# Altium schematic sheet screen.SchDoc(screen)
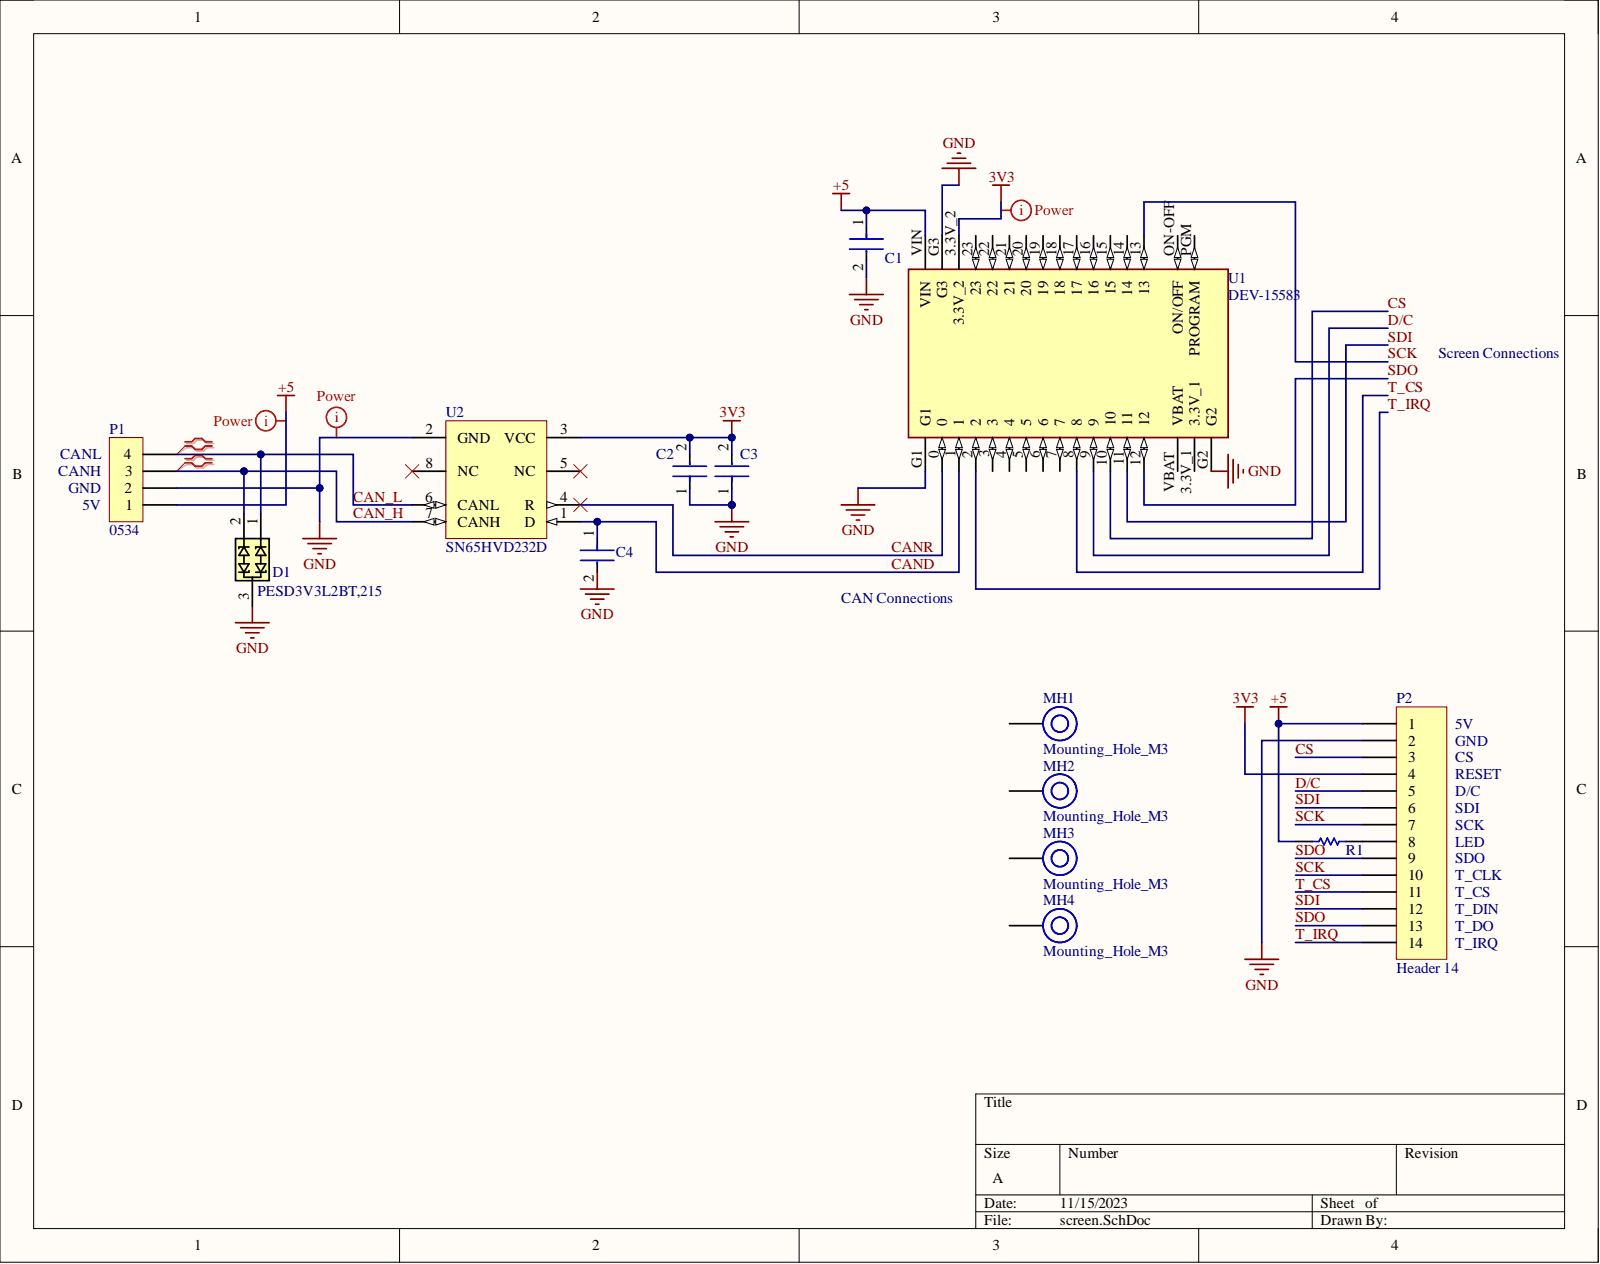
[diagram p0: screen.SchDoc]

## Parts
C1  CL21B104KACNNNC  (value not drawn)
C2  CL21B104KACNNNC  (value not drawn)
C3  CL21B104KACNNNC  (value not drawn)
C4  CL21B103KAANNNC  (value not drawn)
D1  PESD3V3L2BT,215
MH1  Mounting_Hole_M3  (no BOM)
MH2  Mounting_Hole_M3  (no BOM)
MH3  Mounting_Hole_M3  (no BOM)
MH4  Mounting_Hole_M3  (no BOM)
P1  0534
P2  Header 14
R1  RC0805FR-07100RL  (value not drawn)
U1  DEV-15583
U2  SN65HVD232D  [SN65HVD232]

## Nets
3V3: C2.2 C3.2 P2.4 U1.3.3V_2 U2.3(VCC)
+5: C1.1 P1.1 P2.1 R1.1 U1.VIN
GND: C1.2 C2.1 C3.1 C4.2 D1.3 P1.2 P2.2 U1.G1 U1.G2 U1.G3 U2.2(GND)
CAN_H: D1.2 P1.3 U2.7(CANH)
CAN_L: D1.1 P1.4 U2.6(CANL)
CAND: C4.1 U1.1 U2.1(D)
CANR: U1.0 U2.4(R)
CS: P2.3 U1.10
D/C: P2.5 U1.9
SCK: P2.7 P2.10 U1.13
SDI: P2.6 P2.12 U1.11
SDO: P2.9 P2.13 U1.12
T_CS: P2.11 U1.8
T_IRQ: P2.14 U1.2
NetP2_8: P2.8 R1.2

Not connected: MH1 1; MH2 1; MH3 1; MH4 1; U1 3 3.3V_1 4 5 6 7 14 15 16 17 18 19 20 21 22 23 ON-OFF(ON/OFF) PGM(PROGRAM) VBAT; U2 5(NC) 8(NC)

## Library data of the parts
C1-C3 = CMP-13271-002854-1: CL21 Series 0805 100nF 25V ±10% Tolerance X7R Multilayer Ceramic Chip Capacitor; Capacitance: 100nF; Case Code (Imperial): 0805; Case Code (Metric): 2012; Case/Package: 0805; Component Kind: Standard; Contact Plating: Tin; Depth: 1.25mm; Dielectric: X7R; Height: 0.85mm; Length: 2mm; Library Name: Altium Content Vault; Max Operating Temperature: 125°C; Min Operating Temperature: -55°C; Mount: Surface Mount; Package Quantity: 1; Packaging: Tape and Reel; Radiation Hardening: No; Thickness: 0.037inch; Tolerance: 10%; Voltage: 25V; Voltage Rating: 25V; Width: 0.049inch
C4 = CMP-13271-004188-1: CL21 Series 0805 10nF 25V ±10% Tolerance X7R Multilayer Ceramic Chip Capacitor; Tolerance: 20%; Voltage Rating: 50V; Capacitance: 10nF; Case Code (Imperial): 0805; Case Code (Metric): 2012; Case/Package: 0805; Component Kind: Standard; Depth: 1.25mm; Dielectric: X7R; Height: 0.65mm; Length: 2mm; Library Name: Altium Content Vault; Max Operating Temperature: 125°C; Min Operating Temperature: -55°C; Mount: Surface Mount; Package Quantity: 1; Packaging: Tape and Reel; Radiation Hardening: No; Thickness: 0.03inch; Voltage Rating (DC): 50V; Width: 0.049inch
D1 = CMP-00002-6: PESD3V3L2BT - Low capacitance double bidirectional ESD protection diode in SOT23; Component Kind: Standard; Library Name: Pack Motorsports Baja; RoHS Compliant: Yes
MH1-MH4 = CMP-00001-4: Generic M3 Mounting Hole; Library Name: Pack Motorsports Baja
P1 = CMP-00000-3: 2.50mm Pitch Mini-Lock Wire-to-Board Header Right Angle Friction and Positive Lock 4 Circuits; Connector Type: Male Pin; Contact Resistance: 20mR; Number of Contacts: 4; Pitch: 2.5mm; Color: White; Component Kind: Standard; Contact Material: Bronze; Contact Mating Length: 0.157inch; Contact Plating: Tin; Contact Type: Male Pin; Depth: 11.5mm; Fastening Type: Ramp; Housing Color: White; Housing Material: Polybutylene; Length: 12.4mm; Library Name: Pack Motorsports Baja; Max Operating Temperature: 105°C; Min Operating Temperature: -40°C; Mount: Through Hole; Number of Positions: 4; Number of Rows: 1; Orientation: Right Angle; Packaging: Tray; Radiation Hardening: No; Series: Mini-Lock™ 53426; Termination: Solder
P2 = Header 14: Header, 14-Pin; Component Kind: Standard; LatestRevisionDate: 17-Jul-2002; LatestRevisionNote: Re-released for DXP Platform.; Library Name: Miscellaneous Connectors.IntLib; Publisher: Altium Limited
R1 = CMP-2100-03669-1: Chip Resistor, 100 Ohm, +/-1%, 0.125 W, -55 to 155 degC, 0805 (2012 Metric), RoHS, Tape and Reel; Manufacturer: Yageo; Max Power Dissipation: 125mW; Resistance: 100Ohm; Temperature Coefficient: 100ppm/degreesC; Tolerance: 1%; Voltage Rating: 150V; Case Code (Imperial): 0805; Case Code (Metric): 2012; Case/Package: 0805; Component Kind: Standard; Composition: ThickFilm; Datasheet URL: http://www.yageo.com/NewPortal/yageodocoutput?fileName=/pdf/R-Chip/PYu-RC_Group_51_RoHS_L_5.pdf; Datasheet Version: Rev. 5, 10/2016; Depth: 1.25mm; Height: 0.024inch; Lead Free: LeadFree; Lead Pitch: 0.4mm; Length: 2mm; Library Name: Altium Content Vault; Manufacturer URL: http://www.yageo.com/; Max Operating Temperature: 155degC; Military Standard: Not; Min Operating Temperature: -55degC; Number of Pins: 2; Number of Terminations: 2; Package Description: 2-Pin Surface Mounted Device, Body 2 x 1.25 mm; Package Quantity: 1; Package Reference: 0805; Packaging: TapeandReel; Power Rating: 125mW; REACH SVHC: Unknown; RoHSCompliant: true; Termination: SMD/SMT; Voltage Rating (DC): 150V; Width: 0.049inch
U1 = CMP-301-000000-1: RT1062 Teensy 4.0 i.MX ARMÂ® CortexÂ®-M7 MPU Embedded Evaluation Board; MF: SparkFun Electronics; Component Kind: Standard; Component Type: Standard; Design Item ID: DEV-15583; Digi-Key_Part_Number: 1568-DEV-15583-ND; Digi-Key_Purchase_URL: https://www.digikey.com/product-detail/en/sparkfun-electronics/DEV-15583/1568-DEV-15583-ND/10384551?utm_source=snapeda&utm_medium=aggregator&utm_campaign=symbol; Library Name: Pack Motorsports Baja; MP: DEV-15583; Package: None; Pin Count: 33; SnapEDA_Link: https://www.snapeda.com/parts/DEV-15583/SparkFun%20Electronics/view-part/4001229/?ref=snap; Source: SnapEDAsch.SchLib
U2 = CMP-0315-00073-2: 3.3 V CAN Transceiver, 17 mA, -40 to 85 degC, 8-pin SOIC (D), Green (RoHS & no Sb/Br); Manufacturer: Texas Instruments; Drivers Per Package: 1; ICC(Max)(mA): 17; Rating: Catalog; Supply Voltage(s)(V): 3.3; Transient Pulse Protection(V): -25 to 25; Code_JEDEC: MS-012AA; Component Kind: Standard; ComponentLink1Description: Datasheet; ComponentLink1URL: http://www.ti.com/general/docs/lit/getliterature.tsp?genericPartNumber=SN65HVD232&fileType=pdf; DatasheetVersion: SLOS346K; Eco-Plan: Green (RoHS & no Sb/Br); Isolated: No; Library Name: Altium Content Vault; Mounting Technology: Surface Mount; PackageDescription: 8-Pin Plastic Small Outline Integrated Circuit, 4.9 x 3.9 mm, Pitch 1.27 mm Pitch; PackageReference: D0008A; PackageVersion: revM, Jun-2011; PartNumber: SN65HVD232D; Receivers Per Package: 1; SubFamily: CAN
